# Altium schematic sheet Battery Load Share V2.SchDoc
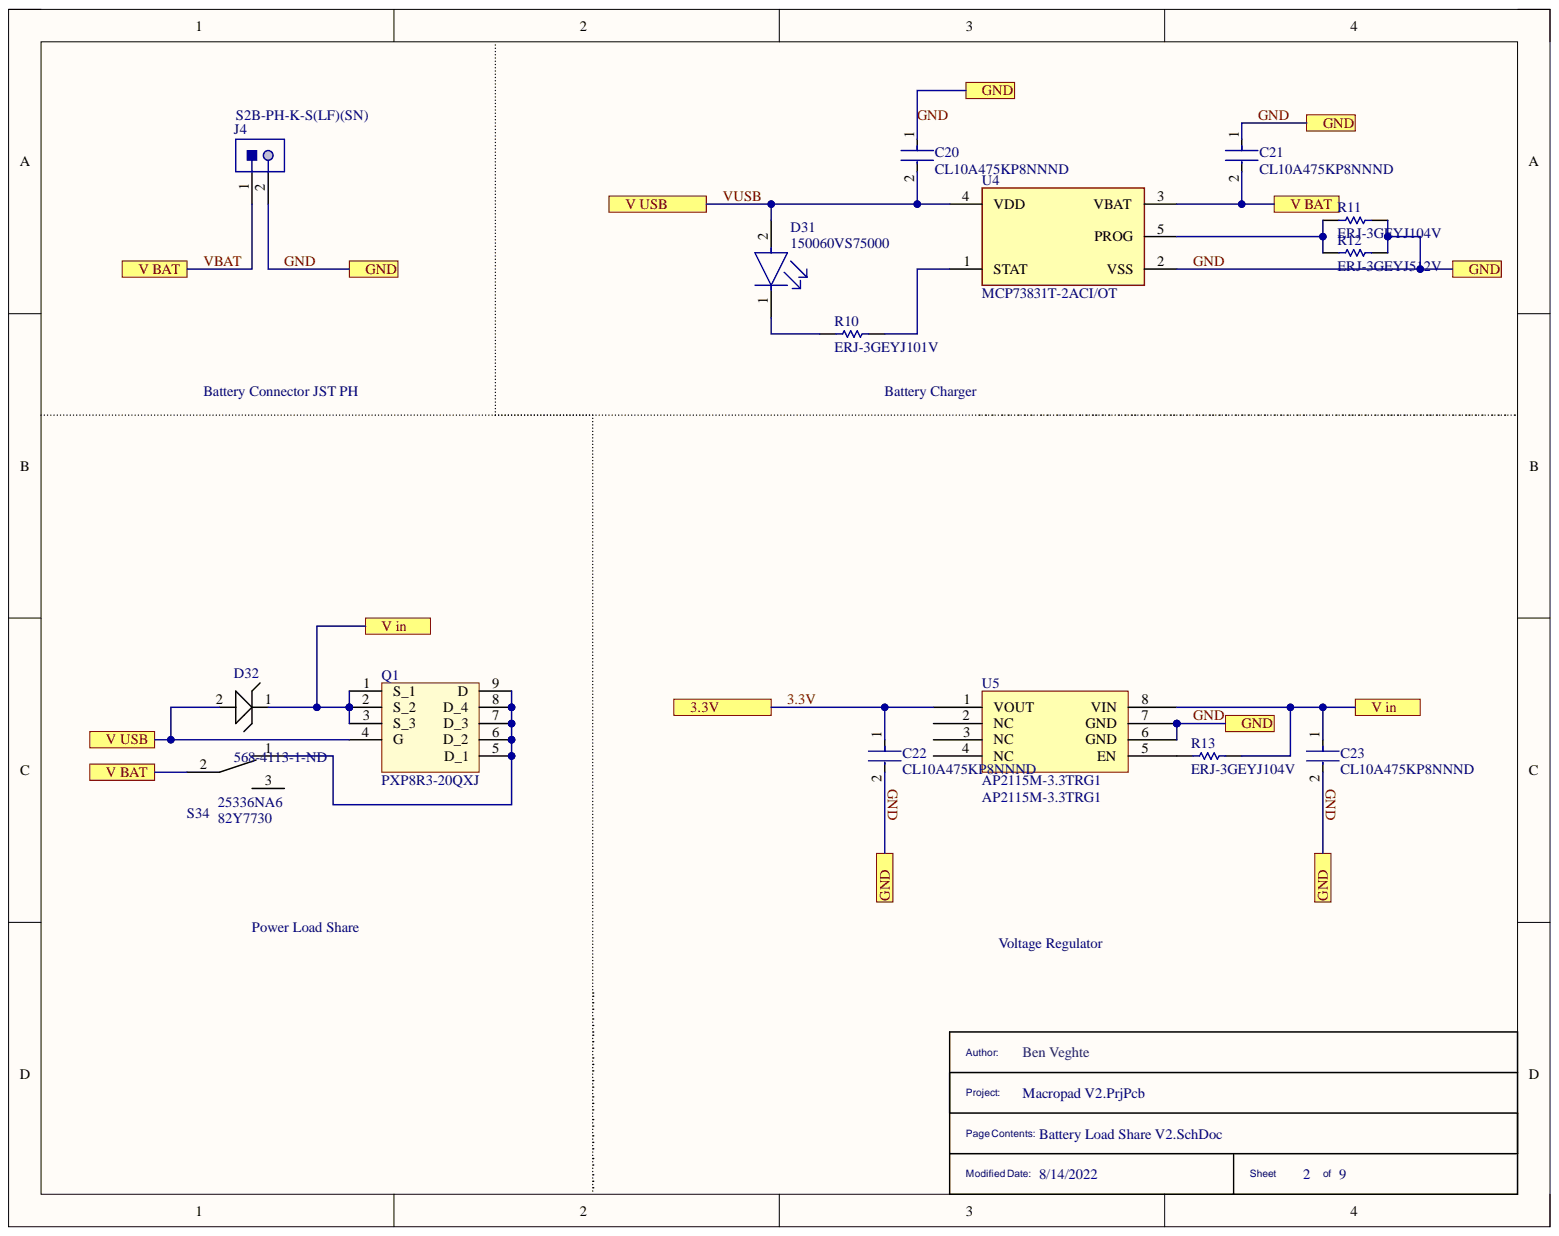
[diagram p0: Battery Load Share V2.SchDoc]

## Parts
C20  CL10A475KP8NNND  [FP-CL10-IPC_C]
C21  CL10A475KP8NNND  [FP-CL10-IPC_C]
C22  CL10A475KP8NNND  [FP-CL10-IPC_C]
C23  CL10A475KP8NNND  [FP-CL10-IPC_C]
D31  150060VS75000  [FP-150060VS75000-MFG]
D32  PMEG2010AEJ115  [PMEG2010AEJ]  (value not drawn)
J4  S2B-PH-K-S(LF)(SN)  [JST-S2B-PH-K-S_V]
Q1  PXP8R3-20QXJ  [PXP8R3-20QXJ]
R10  ERJ-3GEYJ101V  [RESC1608X55X30LL15T15]
R11  ERJ-3GEYJ104V  [RESC1608X55X30NL15T15]
R12  ERJ-3GEYJ512V  [RESC1608X55X30LL15T15]
R13  ERJ-3GEYJ104V  [RESC1608X55X30NL15T15]
S34  25336NA6  Supplier Part Number 1: 82Y7730  [25336NA6]
U4  MCP73831T-2ACI/OT  [FP-C04-091-OT-IPC_B]
U5  AP2115M-3.3TRG1  [AP2115M-3.3TRG1]

## Ports
3.3V, GND, V BAT, V in, V USB

## Nets
3.3V: C22.1 U5.1(VOUT) ; port 3.3V
GND: C20.1 C21.1 C22.2 C23.2 J4.2 R11.2 R12.2 U4.2(VSS) U5.6(GND) U5.7(GND) ; port GND
V in: C23.1 D32.1 Q1.1(S_1) Q1.2(S_2) Q1.3(S_3) R13.2 U5.8(VIN) ; port V in
VBAT: C21.2 J4.1 S34.2 U4.3(VBAT) ; port V BAT
VUSB: C20.2 D31.2 D32.2 Q1.4(G) U4.4(VDD) ; port V USB
NetD31_1: D31.1 R10.1
NetQ1_5: Q1.5(D_1) Q1.6(D_2) Q1.7(D_3) Q1.8(D_4) Q1.9(D) S34.1
NetR10_2: R10.2 U4.1(STAT)
NetR11_1: R11.1 R12.1 U4.5(PROG)
NetR13_1: R13.1 U5.5(EN)

Not connected: S34 3; U5 2(NC) 3(NC) 4(NC)

## Library data of the parts
C20-C23 = CMP-13271-003065-1: Cap Ceramic 4.7uF 10V X5R ±10% Pad SMD 0603 +85°C T/R; Capacitance: 4.7uF; Case/Package: 0603; Component Kind: Standard; Depth: 0.8mm; Dielectric: X5R; Height: 0.8mm; Length: 1.6mm; Library Name: Altium Content Vault; Max Operating Temperature: 85°C; Min Operating Temperature: -55°C; Mount: Surface Mount; Package Quantity: 10000; Packaging: Tape and Reel; Radiation Hardening: No; Thickness: 0.035inch; Tolerance: 10%; Voltage Rating: 10V; Voltage Rating (DC): 10V; Width: 0.031inch
D31 = CMP-1426-00006-5: LED GREEN CLEAR 0603 SMD; Dominant Wavelength: 570nm; Forward Current: 20mA; Forward Voltage: 2V; Lens Color: Colorless; Lens Size: 0.7mm; Lens Style: Rectangular; Luminous Intensity: 40mcd; Power Rating: 72mW; Reverse Voltage (DC): 5V; Viewing Angle: 140°; Case Code (Imperial): 0603; Case Code (Metric): 1608; Case/Package: 0603; Color: Green; Component Kind: Standard; Height: 0.8mm; Illumination Color: Green; Length: 1.6mm; Lens Transparency: Clear; Library Name: Altium Content Vault; Max Operating Temperature: 85°C; Min Operating Temperature: -40°C; Mount: Surface Mount; Number of Pins: 2; Package Quantity: 4000; Packaging: Cut Tape; RoHS Compliant: Yes; Series: WL-SMCW; Test Current: 20mA; Wavelength: 570nm; Weight: 0.000882oz; Width: 0.8mm
D32 = PMEG2010AEJ115: NXP         PMEG2010AEJ,115             Schottky Rectifier, Single, 20 V, 1 A, SOD-323F, 2 Pins, 550 mV; Manufacturer: NXP Semiconductors; Average Rectified Current: 1A; Forward Voltage: 550mV; Max Forward Surge Current (Ifsm): 10A; Max Repetitive Reverse Voltage (Vrrm): 20V; Max Reverse Current: 70uA; Category: Schottky Diodes; Component Kind: Standard; ComponentLink1Description: https://datasheet.ciiva.com/28648/666247-28648370.pdf?src-supplier=Element14; ComponentLink1URL: https://datasheet.ciiva.com/28648/666247-28648370.pdf?src-supplier=Element14; ComponentLink2Description: https://datasheet.ciiva.com/913/pmeg2010aej-913523.pdf?src-supplier=Digi-Key; ComponentLink2URL: https://datasheet.ciiva.com/913/pmeg2010aej-913523.pdf?src-supplier=Digi-Key; ComponentLink3Description: https://datasheet.ciiva.com/4714/pmeg2010aej-117858-4714480.pdf?src-supplier=Mouser; ComponentLink3URL: https://datasheet.ciiva.com/4714/pmeg2010aej-117858-4714480.pdf?src-supplier=Mouser; ComponentLink4Description: https://datasheet.ciiva.com/7965/666247-7965049.pdf?src-supplier=Element14; ComponentLink4URL: https://datasheet.ciiva.com/7965/666247-7965049.pdf?src-supplier=Element14; ComponentLink5Description: https://datasheet.ciiva.com/31423/666247-31423031.pdf?src-supplier=Farnell; ComponentLink5URL: https://datasheet.ciiva.com/31423/666247-31423031.pdf?src-supplier=Farnell; ComponentLink6Description: https://datasheet.ciiva.com/913/pmeg2010aej-913524.pdf?src-supplier=Digi-Key; ComponentLink6URL: https://datasheet.ciiva.com/913/pmeg2010aej-913524.pdf?src-supplier=Digi-Key; ComponentLink7Description: https://datasheet.ciiva.com/913/pmeg2010aej-913525.pdf?src-supplier=Digi-Key; ComponentLink7URL: https://datasheet.ciiva.com/913/pmeg2010aej-913525.pdf?src-supplier=Digi-Key; Element Configuration: Single; Forward Current: 1A; Height: 0.25inch; Lead Free: Lead Free; Length: 0.25inch; Manufacturer Part Number: PMEG2010AEJ115; Max Operating Temperature: 150°C; Max Reverse Leakage Current: 70uA; Max Reverse Voltage (DC): 20V; Max Surge Current: 10A; Min Operating Temperature: -65°C; Mount: Surface Mount; Number of Pins: 2; Package Quantity: 1; Packaging: Tape and Reel; Peak Non-Repetitive Surge Current: 10A; Radiation Hardening: No; REACH SVHC: No SVHC; RoHS: Yes; Supplier 1: Digi-Key; Supplier Part Number 1: 568-4113-1-ND; Termination: SMD/SMT; Weight: 0.01lb; Width: 0.25inch; Library Name: Universal Parts.IntLib
J4 = CMP-1755-00004-1: Male Header, Pitch 2 mm, 1 x 2 Position, Height 4.8 mm, Tail Length 3.4 mm, -25 to 85 degC, RoHS, Bulk; Capacitance: 10 uF; Case\Package: Radial; Tolerance: 20%; Voltage Rating: 50 V; Component Kind: Standard; ComponentLink1Description: Datasheet; ComponentLink1URL: http://www.jst-mfg.com/product/pdf/eng/ePH.pdf; DatasheetVersion: Dec-12; Diameter: 5 mm; Height: 11 mm; Lead Pitch: 2 mm; Length: 11 mm; Library Name: Altium Content Vault; Max Operating Temperature: 85 degC; Min Operating Temperature: -40 degC; Mount: Through Hole; Package Quantity: 200; PackageDescription: Male Header, Pitch 2 mm, 1 x 2 Position, Height 4.8 mm, Tail Length 3.4 mm; PackageReference: S2B-PH-K-S; Packaging: Bulk; Pins: 2; Radiation Hardening: No; REACH SVHC: No SVHC; RoHSCompliant: true; Voltage Rating (DC): 50 V
Q1 = PXP8R3-20QXJ: PXP8R3-20QX - 20 V, P-channel Trench MOSFET; Manufacturer: Nexperia; Category: Uncategorized; Component Kind: Standard; Manufacturer Part Number: PXP8R3-20QXJ; Pricing 1: 1=0.70830, 10=0.62250, 25=0.61630, 50=0.61020, 100=0.46530, 250=0.46060, 500=0.34520, 1000=0.29630 (USD); RoHS: No; Stock 1: 1756; Supplier 1: Arrow Electronics; Supplier Part Number 1: PXP8R3-20QXJ; Library Name: Universal Parts.IntLib
R10 = CMP-2000-00702-1: Manufacturer: Panasonic; Component Kind: Standard; Library Name: Altium Content Vault; Part Number: ERJ-3GEYJ101V
R11 R13 = CMP-2000-00705-1: Manufacturer: Panasonic; Component Kind: Standard; Library Name: Altium Content Vault; Part Number: ERJ-3GEYJ104V
R12 = CMP-2000-00803-1: Manufacturer: Panasonic; Component Kind: Standard; Library Name: Altium Content Vault; Part Number: ERJ-3GEYJ512V
S34 = 25336NA6: Switch Slide ON ON SPDT Side Slide 1A 30VDC 20000Cycles PC Pins Thru-Hole; Manufacturer: APEM; Actuator Length: 6mm; Actuator Material: Nylon,Glass,Polyamide; Actuator Type: Standard; Contact Current Rating: 4A; Electrical Life: 10000Cycles; Illumination Type: Non-Illuminated; Max Voltage Rating (AC): 250V; Max Voltage Rating (DC): 30V; Switch Function: On-On; Switch Travel: 4mm; Voltage Rating (AC): 125V; Category: Slide Switches; Component Kind: Standard; ComponentLink1Description: https://datasheet.ciiva.com/22638/1686052-22638216.pdf?src-supplier=Element14; ComponentLink1URL: https://datasheet.ciiva.com/22638/1686052-22638216.pdf?src-supplier=Element14; Contact Material: Silver; Contact Plating: Silver; Current Rating: 1A; Depth: 13.5mm; Length: 14.1mm; Manufacturer Part Number: 25336NA6; Max Current Rating: 1A; Max Operating Temperature: 85°C; Mechanical Life: 20000Cycles; Min Operating Temperature: -40°C; Mount: Through Hole; Orientation: Right Angle; Package Quantity: 100; Packaging: Bulk; Pricing 1: 1=4.37000, 10=3.91000, 25=3.70000, 50=3.57000, 100=3.38000, 250=3.13000 (USD); REACH SVHC: No SVHC; RoHS: Yes; RoHS Compliant: Yes; Stock 1: 209; Supplier 1: Newark; Termination: PC Pins; Throw Configuration: SPDT; Voltage Rating (DC): 30V; Library Name: Universal Parts.IntLib
U4 = CMP-0133-00143-2: IC CONTROLLR LI-ION 4.2V SOT23-5; Drain to Source Resistance: 350mR; Max Charge Current: 500mA; Max Input Voltage: 6V; Max Output Voltage: 4.5V; Max Power Dissipation: 1.54W; Min Output Voltage: 4.168V; Min Supply Voltage: 3.75V; Number of Cells: 1; Voltage Rating: 4.534V; Case/Package: SOT-23; Component Kind: Standard; Contact Plating: Tin; Height: 0.051inch; Lead Free: Lead Free; Length: 0.122inch; Library Name: Altium Content Vault; Max Operating Temperature: 85°C; Max Supply Voltage: 6V; Min Operating Temperature: -40°C; Mount: Surface Mount; Number of Channels: 1; Number of Outputs: 1; Number of Pins: 5; Output Current: 500mA; Output Voltage: 4.2V; Packaging: Tape and Reel; Radiation Hardening: No; REACH SVHC: No SVHC; Termination: SMD/SMT; Weight: 0.00709oz; Width: 0.071inch
U5 = AP2115M-3.3TRG1: LDO Regulator Pos 3.3V 1A 8-Pin SOIC T/R; Manufacturer: Diodes; Dropout Voltage: 450mV; Number of Regulators: 1; Case/Package: SOIC; Category: Voltage Regulators - Linear; Component Kind: Standard; ComponentLink1Description: http://www.mouser.com/ds/2/115/AP2115-464923.pdf?src-supplier=Mouser; ComponentLink1URL: http://www.mouser.com/ds/2/115/AP2115-464923.pdf?src-supplier=Mouser; ComponentLink2Description: https://www.diodes.com/assets/Datasheets/AP2115.pdf?src-supplier=Digi-Key; ComponentLink2URL: https://www.diodes.com/assets/Datasheets/AP2115.pdf?src-supplier=Digi-Key; Manufacturer Part Number: AP2115M-3.3TRG1; Max Operating Temperature: 85°C; Min Operating Temperature: -40°C; Mount: Surface Mount; Output Current: 1A; Output Voltage: 3.3V; Packaging: Tape and Reel; Pricing 1: 1=0.39860, 10=0.32620, 25=0.30340, 100=0.20830, 250=0.19280, 500=0.15840, 1000=0.11820, 3000=0.11710 (USD); RoHS: Yes; RoHS Compliant: Yes; Stock 1: 3960; Supplier 1: Arrow Electronics; Supplier Part Number 1: AP2115M-3.3TRG1; Library Name: Universal Parts.IntLib
